# Altium schematic sheet [20] - PL BANKS 64-66.SchDoc("[20] - PL BANKS 64-66")
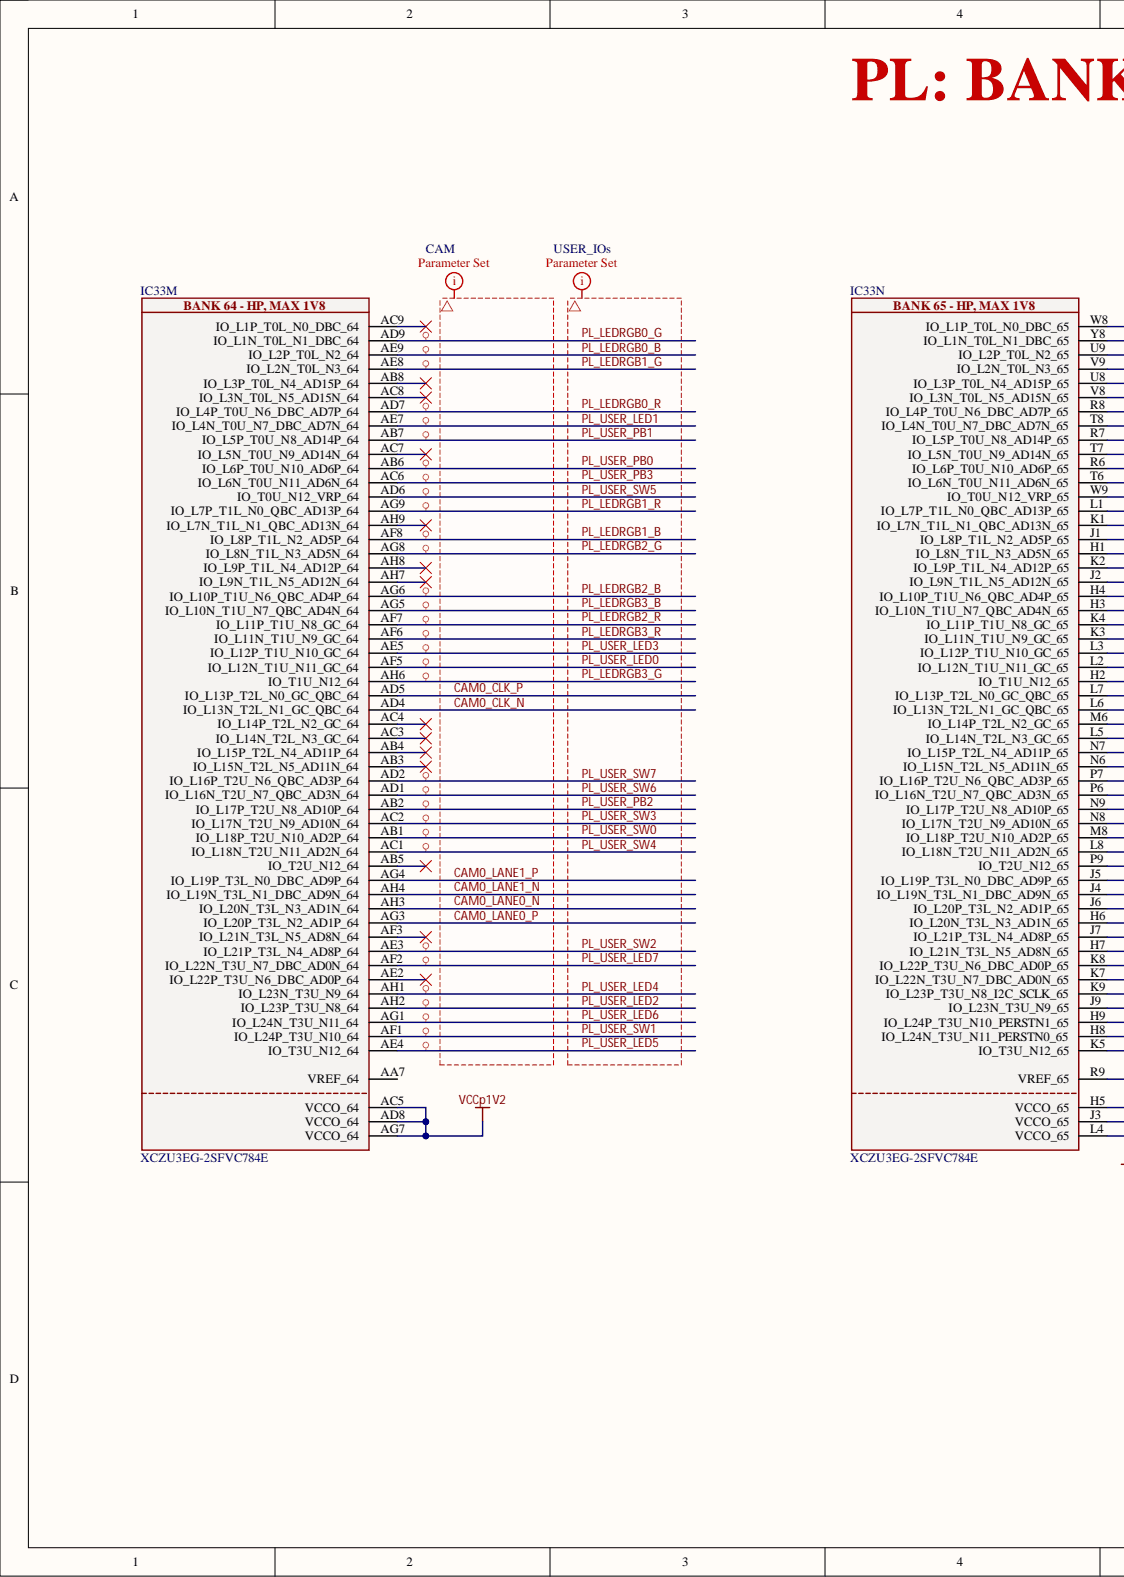
[diagram p0: [20] - PL BANKS 64-66.SchDoc, left]
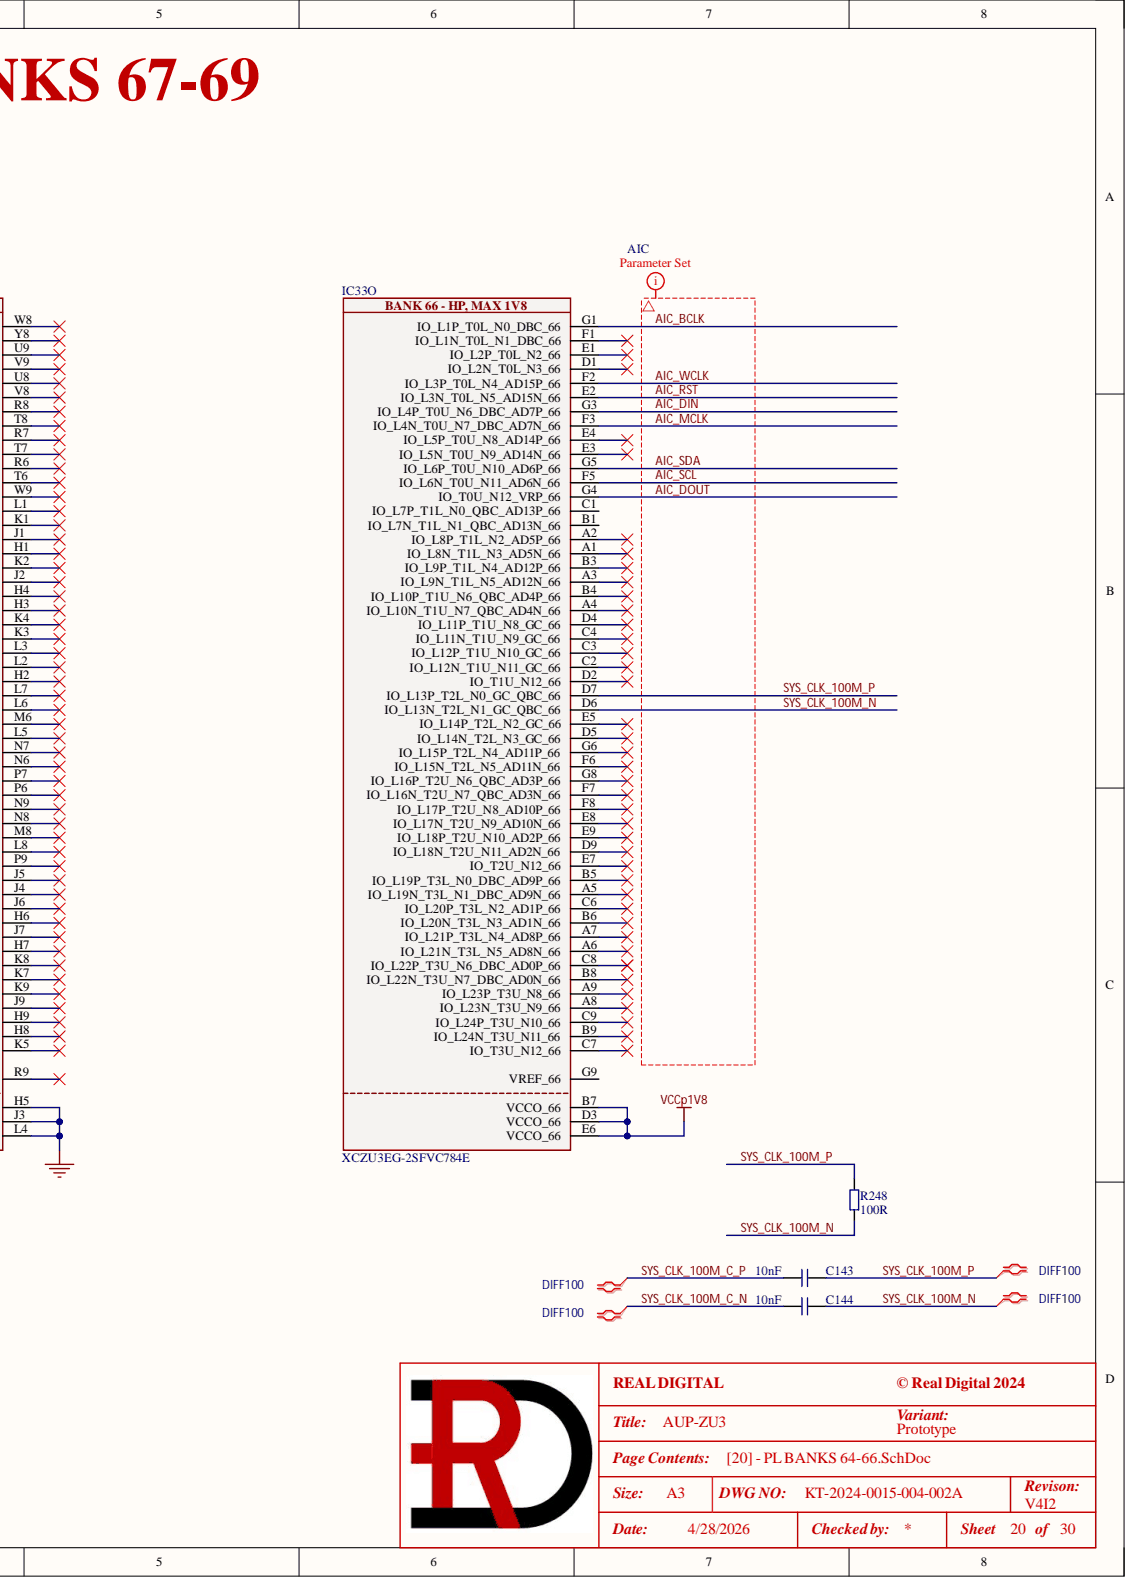
[diagram p1: [20] - PL BANKS 64-66.SchDoc, right]

## Parts
C143  10nF  [C0201]
C144  10nF  [C0201]
IC33  XCZU3EG-2SFVC784E  [SFVC784 - AMD]  (parts M,N,O)
R248  100R  [R0402]

## Nets
GND: IC33.H5(VCCO_65) IC33.J3(VCCO_65) IC33.L4(VCCO_65)
VCCp1V2: IC33.AC5(VCCO_64) IC33.AD8(VCCO_64) IC33.AG7(VCCO_64)
VCCp1V8: IC33.B7(VCCO_66) IC33.D3(VCCO_66) IC33.E6(VCCO_66)
AIC_BCLK: IC33.G1(IO_L1P_T0L_N0_DBC_66)
AIC_DIN: IC33.G3(IO_L4P_T0U_N6_DBC_AD7P_66)
AIC_DOUT: IC33.G4(IO_T0U_N12_VRP_66)
AIC_MCLK: IC33.F3(IO_L4N_T0U_N7_DBC_AD7N_66)
AIC_RST: IC33.E2(IO_L3N_T0L_N5_AD15N_66)
AIC_SCL: IC33.F5(IO_L6N_T0U_N11_AD6N_66)
AIC_SDA: IC33.G5(IO_L6P_T0U_N10_AD6P_66)
AIC_WCLK: IC33.F2(IO_L3P_T0L_N4_AD15P_66)
CAM0_CLK_N: IC33.AD4(IO_L13N_T2L_N1_GC_QBC_64)
CAM0_CLK_P: IC33.AD5(IO_L13P_T2L_N0_GC_QBC_64)
CAM0_LANE0_N: IC33.AH3(IO_L20N_T3L_N3_AD1N_64)
CAM0_LANE0_P: IC33.AG3(IO_L20P_T3L_N2_AD1P_64)
CAM0_LANE1_N: IC33.AH4(IO_L19N_T3L_N1_DBC_AD9N_64)
CAM0_LANE1_P: IC33.AG4(IO_L19P_T3L_N0_DBC_AD9P_64)
PL_LEDRGB0_B: IC33.AE9(IO_L2P_T0L_N2_64)
PL_LEDRGB0_G: IC33.AD9(IO_L1N_T0L_N1_DBC_64)
PL_LEDRGB0_R: IC33.AD7(IO_L4P_T0U_N6_DBC_AD7P_64)
PL_LEDRGB1_B: IC33.AF8(IO_L8P_T1L_N2_AD5P_64)
PL_LEDRGB1_G: IC33.AE8(IO_L2N_T0L_N3_64)
PL_LEDRGB1_R: IC33.AG9(IO_L7P_T1L_N0_QBC_AD13P_64)
PL_LEDRGB2_B: IC33.AG6(IO_L10P_T1U_N6_QBC_AD4P_64)
PL_LEDRGB2_G: IC33.AG8(IO_L8N_T1L_N3_AD5N_64)
PL_LEDRGB2_R: IC33.AF7(IO_L11P_T1U_N8_GC_64)
PL_LEDRGB3_B: IC33.AG5(IO_L10N_T1U_N7_QBC_AD4N_64)
PL_LEDRGB3_G: IC33.AH6(IO_T1U_N12_64)
PL_LEDRGB3_R: IC33.AF6(IO_L11N_T1U_N9_GC_64)
PL_USER_LED0: IC33.AF5(IO_L12N_T1U_N11_GC_64)
PL_USER_LED1: IC33.AE7(IO_L4N_T0U_N7_DBC_AD7N_64)
PL_USER_LED2: IC33.AH2(IO_L23P_T3U_N8_64)
PL_USER_LED3: IC33.AE5(IO_L12P_T1U_N10_GC_64)
PL_USER_LED4: IC33.AH1(IO_L23N_T3U_N9_64)
PL_USER_LED5: IC33.AE4(IO_T3U_N12_64)
PL_USER_LED6: IC33.AG1(IO_L24N_T3U_N11_64)
PL_USER_LED7: IC33.AF2(IO_L22N_T3U_N7_DBC_AD0N_64)
PL_USER_PB0: IC33.AB6(IO_L6P_T0U_N10_AD6P_64)
PL_USER_PB1: IC33.AB7(IO_L5P_T0U_N8_AD14P_64)
PL_USER_PB2: IC33.AB2(IO_L17P_T2U_N8_AD10P_64)
PL_USER_PB3: IC33.AC6(IO_L6N_T0U_N11_AD6N_64)
PL_USER_SW0: IC33.AB1(IO_L18P_T2U_N10_AD2P_64)
PL_USER_SW1: IC33.AF1(IO_L24P_T3U_N10_64)
PL_USER_SW2: IC33.AE3(IO_L21P_T3L_N4_AD8P_64)
PL_USER_SW3: IC33.AC2(IO_L17N_T2U_N9_AD10N_64)
PL_USER_SW4: IC33.AC1(IO_L18N_T2U_N11_AD2N_64)
PL_USER_SW5: IC33.AD6(IO_T0U_N12_VRP_64)
PL_USER_SW6: IC33.AD1(IO_L16N_T2U_N7_QBC_AD3N_64)
PL_USER_SW7: IC33.AD2(IO_L16P_T2U_N6_QBC_AD3P_64)
SYS_CLK_100M_C_N: C144.1
SYS_CLK_100M_C_P: C143.1
SYS_CLK_100M_N: C144.2 IC33.D6(IO_L13N_T2L_N1_GC_QBC_66) R248.1
SYS_CLK_100M_P: C143.2 IC33.D7(IO_L13P_T2L_N0_GC_QBC_66) R248.2

Not connected: IC33 A1(IO_L8N_T1L_N3_AD5N_66) A2(IO_L8P_T1L_N2_AD5P_66) A3(IO_L9N_T1L_N5_AD12N_66) A4(IO_L10N_T1U_N7_QBC_AD4N_66) A5(IO_L19N_T3L_N1_DBC_AD9N_66) A6(IO_L21N_T3L_N5_AD8N_66) A7(IO_L21P_T3L_N4_AD8P_66) A8(IO_L23N_T3U_N9_66) A9(IO_L23P_T3U_N8_66) AA7(VREF_64) AB3(IO_L15N_T2L_N5_AD11N_64) AB4(IO_L15P_T2L_N4_AD11P_64) AB5(IO_T2U_N12_64) AB8(IO_L3P_T0L_N4_AD15P_64) AC3(IO_L14N_T2L_N3_GC_64) AC4(IO_L14P_T2L_N2_GC_64) AC7(IO_L5N_T0U_N9_AD14N_64) AC8(IO_L3N_T0L_N5_AD15N_64) AC9(IO_L1P_T0L_N0_DBC_64) AE2(IO_L22P_T3U_N6_DBC_AD0P_64) AF3(IO_L21N_T3L_N5_AD8N_64) AH7(IO_L9N_T1L_N5_AD12N_64) AH8(IO_L9P_T1L_N4_AD12P_64) AH9(IO_L7N_T1L_N1_QBC_AD13N_64) B1(IO_L7N_T1L_N1_QBC_AD13N_66) B3(IO_L9P_T1L_N4_AD12P_66) B4(IO_L10P_T1U_N6_QBC_AD4P_66) B5(IO_L19P_T3L_N0_DBC_AD9P_66) B6(IO_L20N_T3L_N3_AD1N_66) B8(IO_L22N_T3U_N7_DBC_AD0N_66) B9(IO_L24N_T3U_N11_66) C1(IO_L7P_T1L_N0_QBC_AD13P_66) C2(IO_L12N_T1U_N11_GC_66) C3(IO_L12P_T1U_N10_GC_66) C4(IO_L11N_T1U_N9_GC_66) C6(IO_L20P_T3L_N2_AD1P_66) C7(IO_T3U_N12_66) C8(IO_L22P_T3U_N6_DBC_AD0P_66) C9(IO_L24P_T3U_N10_66) D1(IO_L2N_T0L_N3_66) D2(IO_T1U_N12_66) D4(IO_L11P_T1U_N8_GC_66) D5(IO_L14N_T2L_N3_GC_66) D9(IO_L18N_T2U_N11_AD2N_66) E1(IO_L2P_T0L_N2_66) E3(IO_L5N_T0U_N9_AD14N_66) E4(IO_L5P_T0U_N8_AD14P_66) E5(IO_L14P_T2L_N2_GC_66) E7(IO_T2U_N12_66) E8(IO_L17N_T2U_N9_AD10N_66) E9(IO_L18P_T2U_N10_AD2P_66) F1(IO_L1N_T0L_N1_DBC_66) F6(IO_L15N_T2L_N5_AD11N_66) F7(IO_L16N_T2U_N7_QBC_AD3N_66) F8(IO_L17P_T2U_N8_AD10P_66) G6(IO_L15P_T2L_N4_AD11P_66) G8(IO_L16P_T2U_N6_QBC_AD3P_66) G9(VREF_66) H1(IO_L8N_T1L_N3_AD5N_65) H2(IO_T1U_N12_65) H3(IO_L10N_T1U_N7_QBC_AD4N_65) H4(IO_L10P_T1U_N6_QBC_AD4P_65) H6(IO_L20N_T3L_N3_AD1N_65) H7(IO_L21N_T3L_N5_AD8N_65) H8(IO_L24N_T3U_N11_PERSTN0_65) H9(IO_L24P_T3U_N10_PERSTN1_65) J1(IO_L8P_T1L_N2_AD5P_65) J2(IO_L9N_T1L_N5_AD12N_65) J4(IO_L19N_T3L_N1_DBC_AD9N_65) J5(IO_L19P_T3L_N0_DBC_AD9P_65) J6(IO_L20P_T3L_N2_AD1P_65) J7(IO_L21P_T3L_N4_AD8P_65) J9(IO_L23N_T3U_N9_65) K1(IO_L7N_T1L_N1_QBC_AD13N_65) K2(IO_L9P_T1L_N4_AD12P_65) K3(IO_L11N_T1U_N9_GC_65) K4(IO_L11P_T1U_N8_GC_65) K5(IO_T3U_N12_65) K7(IO_L22N_T3U_N7_DBC_AD0N_65) K8(IO_L22P_T3U_N6_DBC_AD0P_65) K9(IO_L23P_T3U_N8_I2C_SCLK_65) L1(IO_L7P_T1L_N0_QBC_AD13P_65) L2(IO_L12N_T1U_N11_GC_65) L3(IO_L12P_T1U_N10_GC_65) L5(IO_L14N_T2L_N3_GC_65) L6(IO_L13N_T2L_N1_GC_QBC_65) L7(IO_L13P_T2L_N0_GC_QBC_65) L8(IO_L18N_T2U_N11_AD2N_65) M6(IO_L14P_T2L_N2_GC_65) M8(IO_L18P_T2U_N10_AD2P_65) N6(IO_L15N_T2L_N5_AD11N_65) N7(IO_L15P_T2L_N4_AD11P_65) N8(IO_L17N_T2U_N9_AD10N_65) N9(IO_L17P_T2U_N8_AD10P_65) P6(IO_L16N_T2U_N7_QBC_AD3N_65) P7(IO_L16P_T2U_N6_QBC_AD3P_65) P9(IO_T2U_N12_65) R6(IO_L6P_T0U_N10_AD6P_65) R7(IO_L5P_T0U_N8_AD14P_65) R8(IO_L4P_T0U_N6_DBC_AD7P_65) R9(VREF_65) T6(IO_L6N_T0U_N11_AD6N_65) T7(IO_L5N_T0U_N9_AD14N_65) T8(IO_L4N_T0U_N7_DBC_AD7N_65) U8(IO_L3P_T0L_N4_AD15P_65) U9(IO_L2P_T0L_N2_65) V8(IO_L3N_T0L_N5_AD15N_65) V9(IO_L2N_T0L_N3_65) W8(IO_L1P_T0L_N0_DBC_65) W9(IO_T0U_N12_VRP_65) Y8(IO_L1N_T0L_N1_DBC_65)

## Library data of the parts
C143 C144 = GRM033R71E103KE14D: CAP CER 10000pF 25V X7R 0201; Manufacturer 1: Murata Electronics; .Checked_PCB: RV; C P/N: RD-111-0043; LoadStatus: Load; Tolerance: 10%; Category: Capacitors; Component Kind: Standard; Library Name: KT-RealDigital.IntLib; Manufacturer 1 Part Number: GRM033R71E103KE14D; Temperature Coefficient: X7R; Voltage - Rated: 25V; .Checked_SCH: RV; .Creator: RV
IC33 = XCZU3EG-2SFVC784E: Quad ARM® Cortex®-A53 MPCore™ with CoreSight™, Dual ARM®Cortex™-R5 with CoreSight™, ARM Mali™-400 MP2 System On Chip (SOC) IC Zynq® UltraScale+™ MPSoC EG Zynq®UltraScale+™ FPGA, 154K+ Logic Cells 533MHz, 600MHz, 1.3GHz 784-FCBGA (23x23); Manufacturer 1: AMD; .Checked_PCB: RV; C P/N: RD-126-0101-C; LoadStatus: Load; Category: IC; Component Kind: Standard; Library Name: KT-RealDigital.IntLib; Manufacturer 1 Part Number: XCZU3EG-2SFVC784E; .Checked_SCH: RV; .Creator: RV
R248 = RC0402FR-07100RL: RES SMD 100 OHM 1% 1/16W 0402; Manufacturer 1: Yageo; .Checked_PCB: RV; C P/N: RD-101-0011; LoadStatus: Load; Tolerance: 1%/TK100; Category: Resistors; Component Kind: Standard; Library Name: KT-RealDigital.IntLib; Manufacturer 1 Part Number: RC0402FR-07100RL; .Checked_SCH: RV; .Creator: RV
